# Altium schematic sheet 09_ATK-DLMP257_NET2.SchDoc
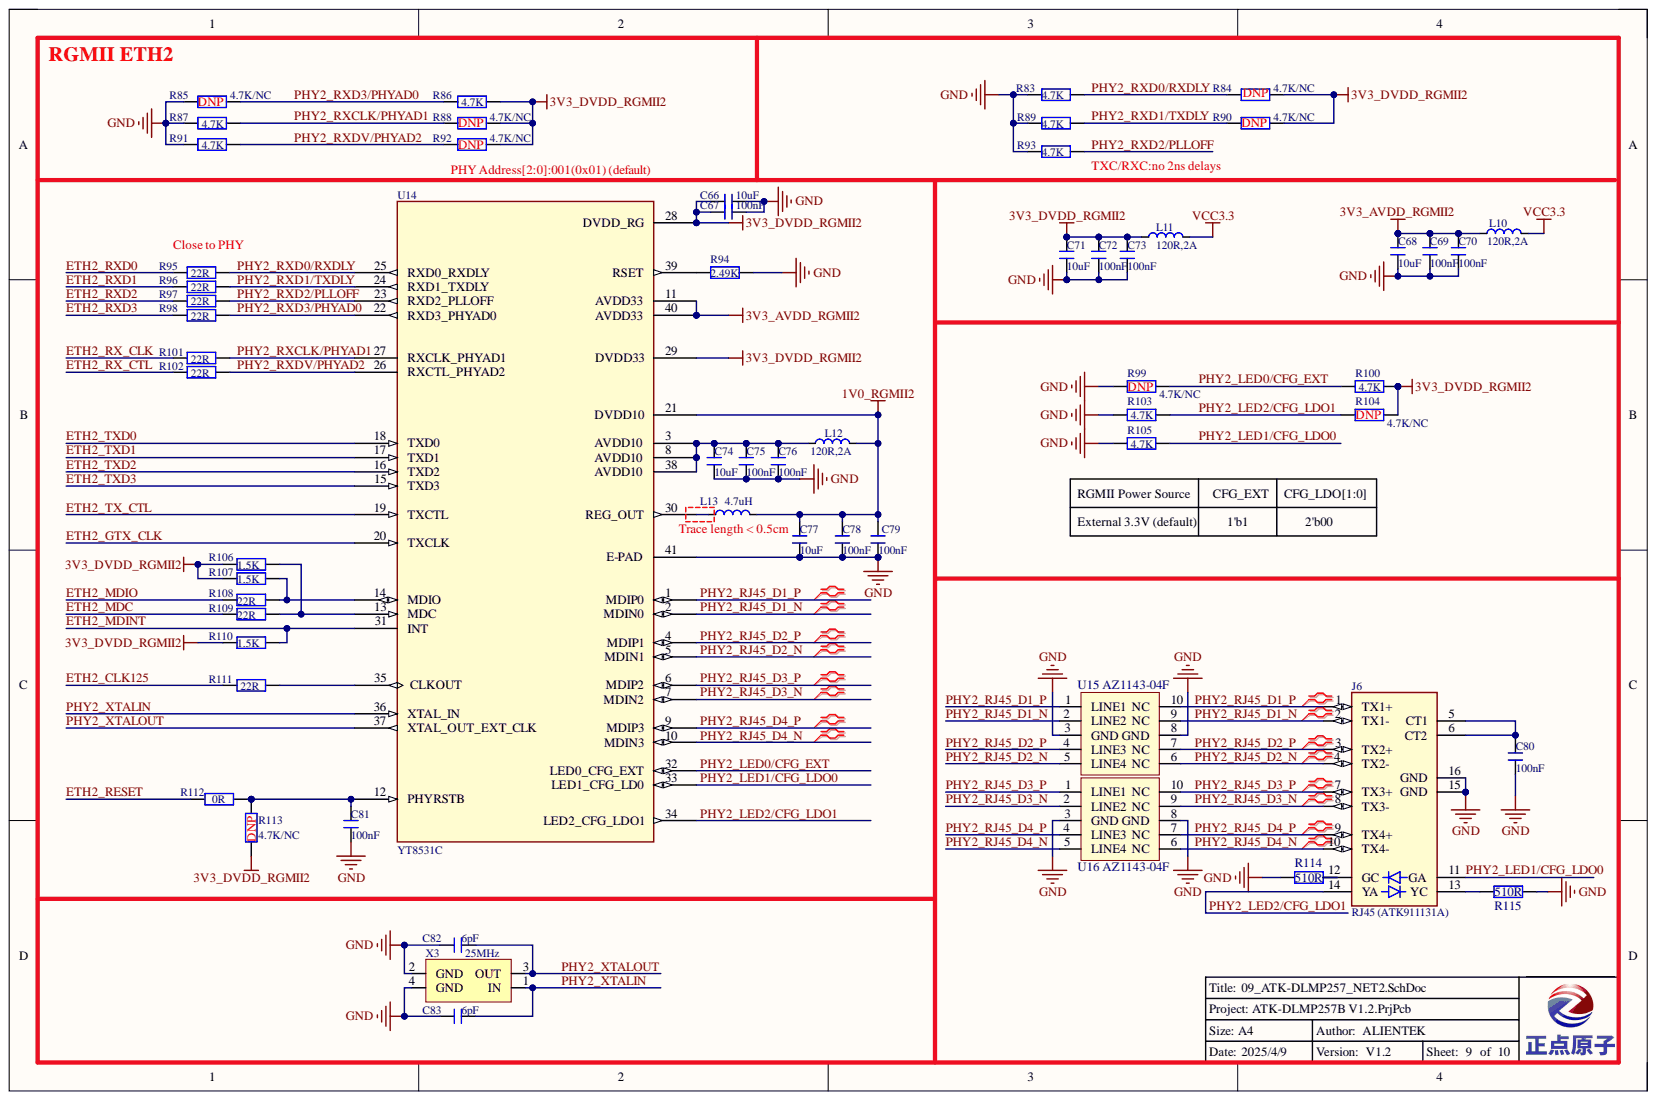
[diagram p0: 09_ATK-DLMP257_NET2.SchDoc]

## Parts
C66  10uF  [C 0603]
C67  100nF  [C 0402]
C68  10uF  [C 0603]
C69  100nF  [C 0402]
C70  100nF  [C 0402]
C71  10uF  [C 0603]
C72  100nF  [C 0402]
C73  100nF  [C 0402]
C74  10uF  [C 0603]
C75  100nF  [C 0402]
C76  100nF  [C 0402]
C77  10uF  [C 0603]
C78  100nF  [C 0402]
C79  100nF  [C 0402]
C80  100nF  [C 0603]
C81  100nF  [C 0402]
C82  6pF  [C 0402]
C83  6pF  [C 0402]
J6  RJ45 (ATK911131A)  [HR911131A]
L10  120R,2A  [L 0603]
L11  120R,2A  [L 0603]
L12  120R,2A  [L 0603]
L13  4.7uH  [L_2520]
R83  4.7K  [R 0402]
R84  4.7K/NC  [R 0402]
R85  4.7K/NC  [R 0402]
R86  4.7K  [R 0402]
R87  4.7K  [R 0402]
R88  4.7K/NC  [R 0402]
R89  4.7K  [R 0402]
R90  4.7K/NC  [R 0402]
R91  4.7K  [R 0402]
R92  4.7K/NC  [R 0402]
R93  4.7K  [R 0402]
R94  2.49K  [R 0402]
R95  22R  [R 0402]
R96  22R  [R 0402]
R97  22R  [R 0402]
R98  22R  [R 0402]
R99  4.7K/NC  [R 0402]
R100  4.7K  [R 0402]
R101  22R  [R 0402]
R102  22R  [R 0402]
R103  4.7K  [R 0603]
R104  4.7K/NC  [R 0603]
R105  4.7K  [R 0603]
R106  1.5K  [R 0402]
R107  1.5K  [R 0402]
R108  22R  [R 0402]
R109  22R  [R 0402]
R110  1.5K  [R 0402]
R111  22R  [R 0402]
R112  0R  [R 0402]
R113  4.7K/NC  [R 0402]
R114  510R  [R 0603]
R115  510R  [R 0603]
U14  YT8531C  [QFN40]
U15  AZ1143-04F  [DFN2510P10E]
U16  AZ1143-04F  [DFN2510P10E]
X3  25MHz  [XTAL-3225]

## Nets
1V0_RGMII2: C77.2 C78.2 C79.2 L12.2 L13.2 U14.21(DVDD10)
3V3_AVDD_RGMII2: C68.2 C69.2 C70.2 L10.1 U14.11(AVDD33) U14.40(AVDD33)
3V3_DVDD_RGMII2: C66.2 C67.2 C71.2 C72.2 C73.2 L11.1 R84.2 R86.2 R88.2 R90.2 R92.2 R100.2 R104.2 R106.1 R107.1 R110.1 R113.2 U14.28(DVDD_RG) U14.29(DVDD33)
GND: C66.1 C67.1 C68.1 C69.1 C70.1 C71.1 C72.1 C73.1 C74.1 C75.1 C76.1 C77.1 C78.1 C79.1 C80.1 C81.1 C82.2 C83.2 J6.15(GND) J6.16(GND) R83.1 R85.2 R87.2 R89.1 R91.2 R93.1 R94.2 R99.1 R103.1 R105.1 R114.1 R115.2 U14.41(E-PAD) U15.3(GND) U15.8(GND) U16.3(GND) U16.8(GND) X3.2(GND) X3.4(GND)
VCC3.3: L10.2 L11.2
ETH2_CLK125: R111.1
ETH2_GTX_CLK: U14.20(TXCLK)
ETH2_MDC: R109.1
ETH2_MDINT: R110.2 U14.31(INT)
ETH2_MDIO: R108.1
ETH2_RESET: R112.1
ETH2_RX_CLK: R101.2
ETH2_RX_CTL: R102.2
ETH2_RXD0: R95.2
ETH2_RXD1: R96.2
ETH2_RXD2: R97.2
ETH2_RXD3: R98.2
ETH2_TX_CTL: U14.19(TXCTL)
ETH2_TXD0: U14.18(TXD0)
ETH2_TXD1: U14.17(TXD1)
ETH2_TXD2: U14.16(TXD2)
ETH2_TXD3: U14.15(TXD3)
PHY2_LED0/CFG_EXT: R99.2 R100.1 U14.32(LED0_CFG_EXT)
PHY2_LED1/CFG_LDO0: J6.11(GA) R105.2 U14.33(LED1_CFG_LD0)
PHY2_LED2/CFG_LDO1: J6.14(YA) R103.2 R104.1 U14.34(LED2_CFG_LDO1)
PHY2_RJ45_D1_N: J6.2(TX1-) U14.2(MDIN0) U15.2(LINE2) U15.9(NC)
PHY2_RJ45_D1_P: J6.1(TX1+) U14.1(MDIP0) U15.1(LINE1) U15.10(NC)
PHY2_RJ45_D2_N: J6.4(TX2-) U14.5(MDIN1) U15.5(LINE4) U15.6(NC)
PHY2_RJ45_D2_P: J6.3(TX2+) U14.4(MDIP1) U15.4(LINE3) U15.7(NC)
PHY2_RJ45_D3_N: J6.8(TX3-) U14.7(MDIN2) U16.2(LINE2) U16.9(NC)
PHY2_RJ45_D3_P: J6.7(TX3+) U14.6(MDIP2) U16.1(LINE1) U16.10(NC)
PHY2_RJ45_D4_N: J6.10(TX4-) U14.10(MDIN3) U16.5(LINE4) U16.6(NC)
PHY2_RJ45_D4_P: J6.9(TX4+) U14.9(MDIP3) U16.4(LINE3) U16.7(NC)
PHY2_RXCLK/PHYAD1: R87.1 R88.1 R101.1 U14.27(RXCLK_PHYAD1)
PHY2_RXD0/RXDLY: R83.2 R84.1 R95.1 U14.25(RXD0_RXDLY)
PHY2_RXD1/TXDLY: R89.2 R90.1 R96.1 U14.24(RXD1_TXDLY)
PHY2_RXD2/PLLOFF: R93.2 R97.1 U14.23(RXD2_PLLOFF)
PHY2_RXD3/PHYAD0: R85.1 R86.1 R98.1 U14.22(RXD3_PHYAD0)
PHY2_RXDV/PHYAD2: R91.1 R92.1 R102.1 U14.26(RXCTL_PHYAD2)
PHY2_XTALIN: C83.1 U14.36(XTAL_IN) X3.1(IN)
PHY2_XTALOUT: C82.1 U14.37(XTAL_OUT_EXT_CLK) X3.3(OUT)
NetC74_2: C74.2 C75.2 C76.2 L12.1 U14.3(AVDD10) U14.8(AVDD10) U14.38(AVDD10)
NetC80_2: C80.2 J6.5(CT1) J6.6(CT2)
NetC81_2: C81.2 R112.2 R113.1 U14.12(PHYRSTB)
NetJ6_12: J6.12(GC) R114.2
NetJ6_13: J6.13(YC) R115.1
NetL13_1: L13.1 U14.30(REG_OUT)
NetR94_1: R94.1 U14.39(RSET)
NetR106_2: R106.2 R109.2 U14.13(MDC)
NetR107_2: R107.2 R108.2 U14.14(MDIO)
NetR111_2: R111.2 U14.35(CLKOUT)

## Library data of the parts
C66-C83 = C: 无极性贴片电容; 版权归属: 源创客; BOM名称: 无极性贴片电容; Component Kind: Standard; 学习资源: www.ooocke.com; Library Name: Lycreturn.IntLib
J6 = HR911131A/C: 千兆以太网接口; Component Kind: Standard; Library Name: 接口类.SchLib
L10-L13 = L: 小功率贴片电感磁珠; 版权归属: 源创客; BOM名称: 小功率贴片电感; Component Kind: Standard; Library Name: 电阻-电容-电感.SchLib; 学习资源: www.ooocke.com
R83-R113 = R: 贴片电阻; 版权归属: 源创客; BOM名称: 贴片电阻; Component Kind: Standard; 学习资源: www.ooocke.com; Library Name: Lycreturn.IntLib
R114 R115 = R: 贴片电阻; 版权归属: 正点原子; BOM名称: 贴片电阻; Component Kind: Standard; 学习资源: www.openedv.com; Library Name: Lycreturn.IntLib
U14 = RTL8211F-CG: Component Kind: Standard; Library Name: ZZK_COMPONENT.IntLib
U15 U16 = AZ1143-04F: ESD保护二极管阵列; Component Kind: Standard; Library Name: 二极管-整流桥.SchLib
X3 = XTAL-4P: 4脚无源晶振; BOM名称: 4脚晶振; 版权归属: 源创客; Component Kind: Standard; 学习资源: www.ooocke.com; Library Name: Lycreturn.IntLib
